# Altium schematic sheet FTDI.SchDoc(FTDI)
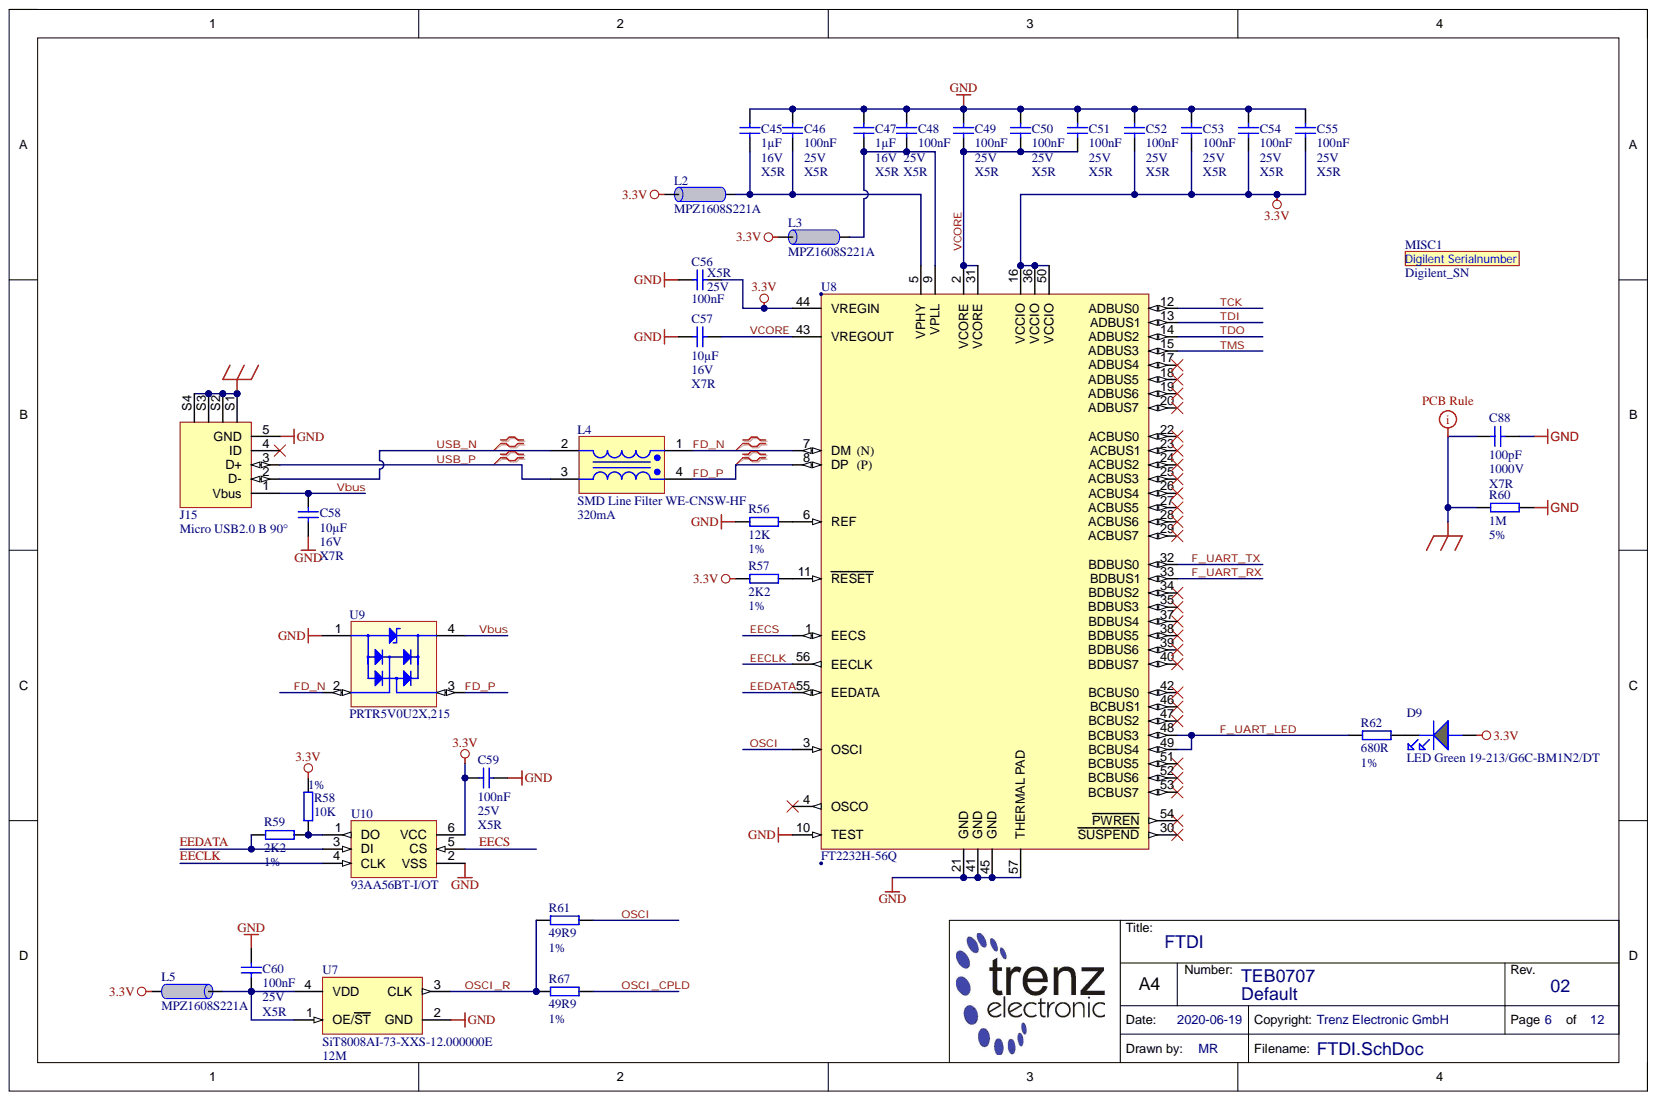
[diagram p0: FTDI.SchDoc]

## Parts
C45  1µF  Technology: X5R  Voltage: 16V  [CAPC1005X55N_V]
C46  100nF  Technology: X5R  Voltage: 25V  [CAPC1005X55N]
C47  1µF  Technology: X5R  Voltage: 16V  [CAPC1005X55N_V]
C48  100nF  Technology: X5R  Voltage: 25V  [CAPC1005X55N]
C49  100nF  Technology: X5R  Voltage: 25V  [CAPC1005X55N]
C50  100nF  Technology: X5R  Voltage: 25V  [CAPC1005X55N]
C51  100nF  Technology: X5R  Voltage: 25V  [CAPC1005X55N]
C52  100nF  Technology: X5R  Voltage: 25V  [CAPC1005X55N]
C53  100nF  Technology: X5R  Voltage: 25V  [CAPC1005X55N]
C54  100nF  Technology: X5R  Voltage: 25V  [CAPC1005X55N]
C55  100nF  Technology: X5R  Voltage: 25V  [CAPC1005X55N]
C56  100nF  Technology: X5R  Voltage: 25V  [CAPC1005X55N]
C57  10µF  Voltage: 16V  [CAPC2012X135N]
C58  10µF  Technology: X7R  Voltage: 16V  [CAPC2012X135N]
C59  100nF  Voltage: 25V  [CAPC1005X55N]
C60  100nF  Voltage: 25V  [CAPC1005X55N]
C88  100pF  [CAPC3216X180N]
D9  LED Green 19-213/G6C-BM1N2/DT  Database Table Name: LED  H-ART: 19-213/G6C-BM1N2/DT  [LEDC1608X55N_GREEN]
J15  Micro USB2.0 B 90°  [629105150521]
L2  MPZ1608S221A  [INDC1608X95N]
L3  MPZ1608S221A  [INDC1608X95N]
L4  SMD Line Filter WE-CNSW-HF  Voltage: 320mA  [WE-CNSW-HF]
L5  MPZ1608S221A  [INDC1608X95N]
MISC1  Digilent_SN  (mechanical)
R56  12K  [RESC1005X40N]
R57  2K2  Tolerance: 1%  [RESC1005X40N]
R58  10K  Tolerance: 1%  [RESC1005X40N]
R59  2K2  Tolerance: 1%  [RESC1005X40N]
R60  1M  Tolerance: 5%  [RESC3216X65N]
R61  49R9  Tolerance: 1%  [RESC1005X40N]
R62  680R  Tolerance: 1%  [RESC1005X40N]
R67  49R9  Tolerance: 1%  [RESC1005X40N]
U7  SiT8008AI-73-XXS-12.000000E  value 12M  Database Table Name: CLK  [2016]
U8  FT2232H-56Q  [QFN50P800X800-57T610X610N]
U9  PRTR5V0U2X,215  [SOT190P229X114-4N]
U10  93AA56BT-I/OT  [SOT95P280X145-6N]

## Nets
3.3V: C52.2 C53.2 C54.2 C55.2 C56.2 C59.2 D9.A L2.2 L3.2 L5.1 R57.1 R58.1 U8.16(VCCIO) U8.36(VCCIO) U8.44(VREGIN) U8.50(VCCIO) U10.6(VCC)
FGND_FT: C88.1 J15.S1 J15.S2 J15.S3 J15.S4 R60.1
GND: C45.1 C46.1 C47.1 C48.1 C49.1 C50.1 C51.1 C52.1 C53.1 C54.1 C55.1 C56.1 C57.1 C58.1 C59.1 C60.2 C88.2 J15.5(GND) R56.1 R60.2 U7.2(GND) U8.10(TEST) U8.21(GND) U8.41(GND) U8.45(GND) U8.57(THERMAL_PAD) U9.1 U10.2(VSS)
EECLK: U8.56(EECLK) U10.4(CLK)
EECS: U8.1(EECS) U10.5(CS)
EEDATA: R59.1 U8.55(EEDATA) U10.3(DI)
F_UART_LED: R62.2 U8.48(BCBUS3) U8.49(BCBUS4)
F_UART_RX: U8.33(BDBUS1)
F_UART_TX: U8.32(BDBUS0)
FD_N: L4.1 U8.7(DM) U9.2
FD_P: L4.4 U8.8(DP) U9.3
OSCI: R61.2 U8.3(OSCI)
OSCI_CPLD: R67.2
OSCI_R: R61.1 R67.1 U7.3(CLK)
TCK: U8.12(ADBUS0)
TDI: U8.13(ADBUS1)
TDO: U8.14(ADBUS2)
TMS: U8.15(ADBUS3)
USB_N: J15.2(D-) L4.2
USB_P: J15.3(D+) L4.3
Vbus: C58.2 J15.1(Vbus) U9.4
VCORE: C49.2 C50.2 C51.2 C57.2 U8.2(VCORE) U8.31(VCORE) U8.43(VREGOUT)
NetC45_2: C45.2 C46.2 L2.1 U8.5(VPHY)
NetC47_2: C47.2 C48.2 L3.1 U8.9(VPLL)
NetC60_1: C60.1 L5.2 U7.1(OE/ST) U7.4(VDD)
NetD9_K: D9.K R62.1
NetR56_2: R56.2 U8.6(REF)
NetR57_2: R57.2 U8.11(RESET)
NetR58_2: R58.2 R59.2 U10.1(DO)

Not connected: J15 4(ID); U8 4(OSCO) 17(ADBUS4) 18(ADBUS5) 19(ADBUS6) 20(ADBUS7) 22(ACBUS0) 23(ACBUS1) 24(ACBUS2) 25(ACBUS3) 26(ACBUS4) 27(ACBUS5) 28(ACBUS6) 29(ACBUS7) 30(SUSPEND) 34(BDBUS2) 35(BDBUS3) 37(BDBUS4) 38(BDBUS5) 39(BDBUS6) 40(BDBUS7) 42(BCBUS0) 46(BCBUS1) 47(BCBUS2) 51(BCBUS5) 52(BCBUS6) 53(BCBUS7) 54(PWREN)

## Library data of the parts
C45 C47 = 26579: KON 1µF 16V X5R 10% SMD BF 1005 (0402); Database Table Name: C_1005_0402; Mixed: SMT; Temperature-Range: -55/+85; Value: 1u; AN: 26579; Case: 1005 (0402); Component Kind: Standard; Library Name: TE.DbLib; Tolerance: 10%
C46 C48-C56 C59 C60 = 22472: KON 100nF 25V X5R ±10% SMD BF 1005 (0402); Database Table Name: C_1005_0402; Mixed: SMT; Preferred: Yes; Temperature-Range: -55/+85; Value: 100n; AN: 22472; Case: 1005 (0402); Component Kind: Standard; Library Name: TE.DbLib
  C59 C60: Technology: X5R
C57 C58 = 24380: KON 10µF 16V X7R 10% SMD BF 2012 (0805); Database Table Name: C_2012_0805; Mixed: SMT; Preferred: Yes; Temperature-Range: -55/+125; Value: 10u; AN: 24380; Case: 2012 (0805); Component Kind: Standard; Library Name: TE.DbLib; Tolerance: 10%
  C57: Technology: X7R
C88 = 22679: KON 100pF 1000V X7R 10%  BF 3216 (1206); Database Table Name: C_3216_1206; Mixed: SMT; Preferred: Yes; Temperature-Range: -55/+125; Value: 100p; AN: 22679; Case: 3216 (1206); Component Kind: Standard; Library Name: TE.DbLib; Technology: X7R; Tolerance: 10%; Voltage: 1000V
D9 = 28262: Chip LED 0603 Briliant Green; Extra: Led Green SMD 0603; Mixed: SMT; Temperature-Range: -40/+85 (Abs); AN: 28262; Case: 1608 (0603); Component Kind: Standard; Library Name: TE.DbLib; HelpURL: b:\AD\adlib\datasheet\19-213-G6C-BM1\
J15 = 27235: Micro USB2.0 B Receptacle 90° SMD/THT; Database Table Name: CON_IO; Mixed: SMT; Temperature-Range: -40/+85; AN: 27235; Component Kind: Standard; Extra: 629105150521; H-ART: 629105150521; Library Name: TE.DbLib; HelpURL: B:\AD\adlib\datasheet\629105150521
L2 L3 L5 = 22718: Ferritdurchführung MPZ1608S221A; Database Table Name: L_1608_0603; Extra: 0,05 Ohm DC, 220 Ohm bei 100MHz. 2A; Mixed: SMT; Preferred: Yes; Value: 220 OHm @ 100MHz; AN: 22718; Case: 1608 (0603); Component Kind: Standard; H-ART: MPZ1608S221A; Library Name: TE.DbLib; Trenz-Stock: Yes; HelpURL: B:\AD\adlib\datasheet\MPZ1608
L4 = 24514: Stromkompensierter SMD Line Filter HF 320mA 0,24Ohm 50V; Database Table Name: L_SMD; Extra: 67 Ohm bei 100MHz; H-ART: 744233670; Mixed: SMT; Preferred: Yes; AN: 24514; Component Kind: Standard; Library Name: TE.DbLib; Technology: 0.24Ohm; Trenz-Stock: Yes; HelpURL: B:\AD\adlib\datasheet\WE-CNSW HF
MISC1 = 27065: Digilent Serialnumber; Database Table Name: PCB; AN: 27065; Library Name: TE.DbLib
R56 = 22598: WID SMD 12K 1% 0,063W  BF 1005 (0402); Database Table Name: R_1005_0402; Mixed: SMT; Preferred: Yes; AN: 22598; Case: 1005 (0402); Component Kind: Standard; Library Name: TE.DbLib; Technology: TK100; Tolerance: 1%; Value: 12K
R57 R59 = 22748: WID SMD 2K2 1% 0,063W BF 1005 (0402); Database Table Name: R_1005_0402; H-ART: RC0402FR-132K2L; Mixed: SMT; Preferred: Yes; Value: 2.2K; AN: 22748; Case: 1005 (0402); Component Kind: Standard; Library Name: TE.DbLib; Technology: TK100
R58 = 22750: WID SMD 10K 1% 0,063W BF 1005 (0402); Database Table Name: R_1005_0402; H-ART: RC0402FR-0710KL; Mixed: SMT; Preferred: Yes; AN: 22750; Case: 1005 (0402); Component Kind: Standard; Library Name: TE.DbLib; Technology: TK100; Value: 10K
R60 = 22757: WID SMD 1M 5% 0,25W  BF 3216 (1206); Database Table Name: R_3216_1206; Mixed: SMT; Preferred: Yes; AN: 22757; Case: 3216 (1206); Component Kind: Standard; Library Name: TE.DbLib; Technology: TK100; Value: 1M
R61 R67 = 23135: WID SMD 49R9 1% 0,063W  BF 1005 (0402); Database Table Name: R_1005_0402; H-ART: RC0402FR-0749R9L; Mixed: SMT; Preferred: Yes; Value: 49.9; AN: 23135; Case: 1005 (0402); Component Kind: Standard; Library Name: TE.DbLib; Technology: TK100
R62 = 22761: WID SMD 680R 1% 0,063W; Database Table Name: R_1005_0402; Extra: BF 1005 (0402); H-ART: RC0402FR-13680RL; Mixed: SMT; Preferred: Yes; Value: 680; AN: 22761; Case: 1005 (0402); Component Kind: Standard; Library Name: TE.DbLib; Technology: TK100
U7 = 25325: SiT8008AI-73-XXS-12.000000E MEMS Oszillator 12MHz; Extra: 2,25 - 3,63V , -40° C - 85° C, +/-50 ppm, 2 x1,6 mm; Mixed: SMT; Preferred: Yes; Temperature-Range: -40/+85; AN: 25325; Case: 2.0  x 1.6mm; Component Kind: Standard; H-ART: SiT8008AI-73-XXS-12.000000E; Library Name: TE.DbLib; Trenz-Stock: Yes; HelpURL: B:\AD\adlib\datasheet\SiT8008
U8 = 27277: Database Table Name: IF; Extra: Dual High Speed USB to Multipurpose UART/FIFO IC; Mixed: SMT; Preferred: Yes; Temperature-Range: -40/+85; AN: 27277; Case: VQFN56; Component Kind: Standard; Description: FT2232H-56Q; H-ART: FT2232H-56Q; Library Name: TE.DbLib; Storage-Temperature: -65/+150(Abs); Trenz-Stock: Yes; HelpURL: B:\AD\adlib\datasheet\FT2232H
U9 = 24840: Database Table Name: DIODE; Extra: Steering/TVS Diode Array Series; Mixed: SMT; Preferred: Yes; Temperature-Range: -40/+85; AN: 24840; Case: SOT143; Component Kind: Standard; Description: PRTR5V0U2X,215; H-ART: PRTR5V0U2X,215; Library Name: TE.DbLib; HelpURL: B:\AD\adlib\datasheet\CD143A-SR05
U10 = 24730: Database Table Name: EEPROM; Extra: 2K Microwire Compatible Serial EEPROM 128x16 - 1.8V; Mixed: SMT; Preferred: Yes; Temperature-Range: -40/+85; AN: 24730; Case: SOT23-6; Component Kind: Standard; Description: 93AA56BT-I/OT; H-ART: 93AA56BT-I/OT; Library Name: TE.DbLib; Trenz-Stock: Yes; HelpURL: B:\AD\adlib\datasheet\93AA56A
